# Altium schematic sheet JacdacModuleTesterEc30 91.SchDoc
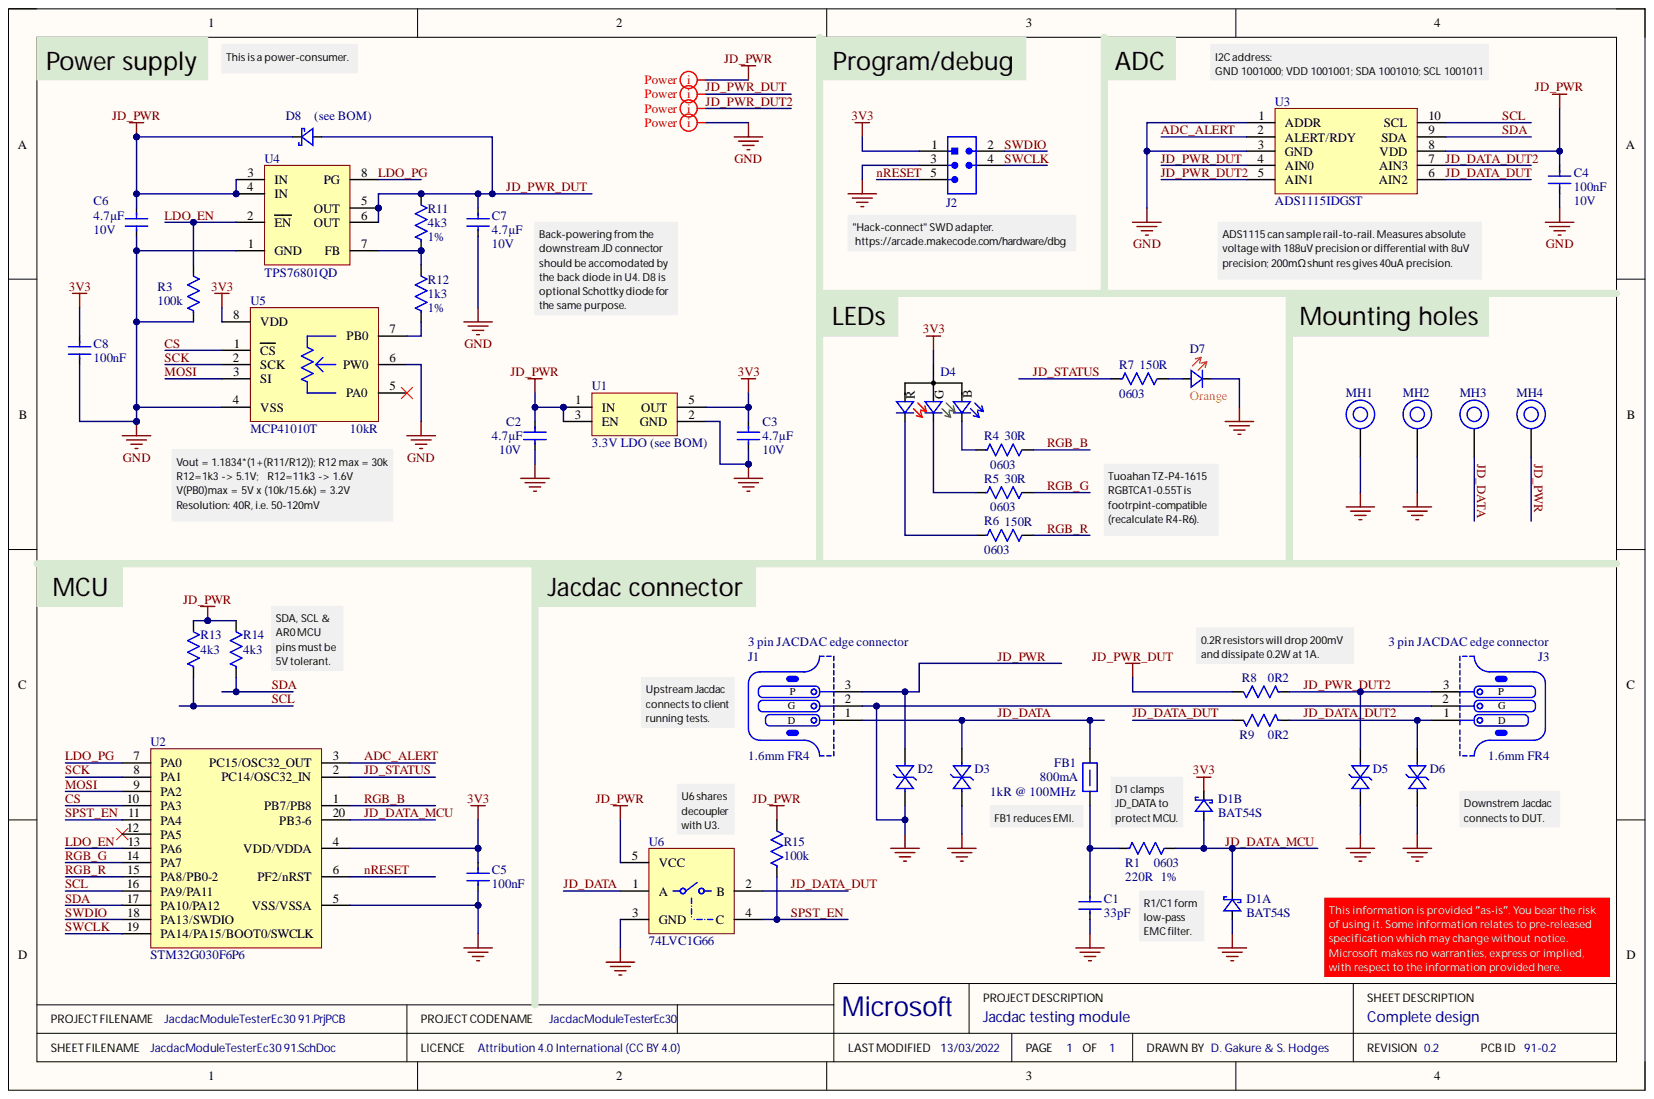
[diagram p0: JacdacModuleTesterEc30 91.SchDoc]

## Parts
C1  value 33pF
C2  Capacitance: 4.7µF  Voltage Rating: 10V
C3  Capacitance: 4.7µF  Voltage Rating: 10V
C4  value 100nF  Rated Voltage: 10V
C5  value 100nF
C6  Capacitance: 4.7µF  Voltage Rating: 10V
C7  Capacitance: 4.7µF  Voltage Rating: 10V
C8  value 100nF
D1  Generic Part Number: BAT54S  (parts A,B)
D2  (no value)
D3  (no value)
D4  (no value)
D5  (no value)
D6  (no value)
D7  Color: Orange
D8  Generic Part Number: (see BOM)
FB1  Current Rating: 800mA
J1  PCB Type: 1.6mm FR4
J2  (no value)
J3  PCB Type: 1.6mm FR4
MH1  (no value)
MH2  (no value)
MH3  (no value)
MH4  (no value)
R1  Case Code (Imperial): 0603  Resistance: 220R  Resistance Tolerance: 1%
R3  value 100k
R4  Case Code (Imperial): 0603  Resistance: 30R
R5  Case Code (Imperial): 0603  Resistance: 30R
R6  Case Code (Imperial): 0603  Resistance: 150R
R7  Case Code (Imperial): 0603  Resistance: 150R
R8  value 0R2
R9  value 0R2
R11  value 4k3  Tolerance: 1%
R12  value 1k3  Tolerance: 1%
R13  value 4k3
R14  value 4k3
R15  value 100k
U1  Manufacturer Part Number: 3.3V LDO (see BOM)  Min Output Voltage: 3.3V
U2  Manufacturer Part Number: STM32G030F6P6
U3  Manufacturer Part Number: ADS1115IDGST
U4  Manufacturer Part Number: TPS76801QD
U5  Resistance: 10kR
U6  (no value)

## Nets
3V3: C3.2 C5.2 C8.2 D1.2 D4.1 J2.1 U1.5(OUT) U2.4(VDD/VDDA) U5.8(VDD)
GND: C1.1 C2.1 C3.1 C4.1 C5.1 C6.1 C7.1 C8.1 D1.1 D2.2 D3.2 D5.2 D6.2 D7.1 J1.2 J2.3 J3.2 MH1.1 MH2.1 R3.2 U1.2(GND) U2.5(VSS/VSSA) U3.1(ADDR) U3.3(GND) U4.1(GND) U5.4(VSS) U5.6(PW0) U6.3(GND)
ADC_ALERT: U2.3(PC15/OSC32_OUT) U3.2(ALERT/RDY)
CS: U2.10(PA3) U5.1(CS)
JD_DATA: D3.1 FB1.1 J1.1 MH3.1 U6.1(A)
JD_DATA_DUT: R9.1 U3.6(AIN2) U6.2(B)
JD_DATA_DUT2: D6.1 J3.1 R9.2 U3.7(AIN3)
JD_DATA_MCU: D1.3 R1.2 U2.20(PB3-6)
JD_PWR: C2.2 C4.2 C6.2 D2.1 D8.1 J1.3 MH4.1 R13.1 R14.1 R15.1 U1.1(IN) U1.3(EN) U3.8(VDD) U4.3(IN) U4.4(IN) U6.5(VCC)
JD_PWR_DUT: C7.2 D8.2 R8.1 R11.1 U3.4(AIN0) U4.5(OUT) U4.6(OUT)
JD_PWR_DUT2: D5.1 J3.3 R8.2 U3.5(AIN1)
JD_STATUS: R7.1 U2.2(PC14/OSC32_IN)
LDO_EN: R3.1 U2.13(PA6) U4.2(EN)
LDO_PG: U2.7(PA0) U4.8(PG)
MOSI: U2.9(PA2) U5.3(SI)
nRESET: J2.5 U2.6(PF2/nRST)
RGB_B: R4.2 U2.1(PB7/PB8)
RGB_G: R5.2 U2.14(PA7)
RGB_R: R6.2 U2.15(PA8/PB0-2)
SCK: U2.8(PA1) U5.2(SCK)
SCL: R13.2 U2.16(PA9/PA11) U3.10(SCL)
SDA: R14.2 U2.17(PA10/PA12) U3.9(SDA)
SPST_EN: R15.2 U2.11(PA4) U6.4(C)
SWCLK: J2.4 U2.19(PA14/PA15/BOOT0/SWCLK)
SWDIO: J2.2 U2.18(PA13/SWDIO)
NetC1_2: C1.2 FB1.2 R1.1
NetD4_2: D4.2 R6.1
NetD4_3: D4.3 R5.1
NetD4_4: D4.4 R4.1
NetD7_2: D7.2 R7.2
NetR11_2: R11.2 R12.1 U4.7(FB)
NetR12_2: R12.2 U5.7(PB0)

Not connected: U2 12(PA5); U5 5(PA0)

## Library data of the parts
C1 = (no symbol name): Generic 0603 capacitor 33pF; Rated Voltage: 10V; Manufacturer: Generic; Manufacturer 1: Generic; Package Size: 0603
C2 C3 C6 C7 = (no symbol name): Generic 0603 capacitor 4.7uF 10V, e.g. Samsung CL10A475KP8NNNC; Dielectric: X5R; Tolerance: 10%; Case Code (Imperial): 0603; Case Code (Metric): 1608; Case/Package: 0603; Contact Plating: Tin; Manufacturer: Samsung; Manufacturer Part Number: CL10A475KP8NNNC; Max Operating Temperature: 85°C; Min Operating Temperature: -55°C; Supplier 1: Digi-Key; Supplier 2: Farnell; Supplier Part Number 1: 1276-1044-1-ND; Supplier Part Number 2: 3010071
C4 = (no symbol name): Generic 0603 capacitor 100nF; Manufacturer: Generic; Manufacturer 1: Generic; Package Size: 0603
C5 C8 = (no symbol name): Generic 0603 capacitor 100nF; Rated Voltage: 10V; Manufacturer: Generic; Manufacturer 1: Generic; Package Size: 0603
D1 = (no symbol name): DIODE ARRAY SCHOTTKY 30V SOT23; Manufacturer: Nexperia; Manufacturer Part Number: BAT54S,235; Category: Rectifier Diodes; ComponentLink1Description: NXP datasheet online; ComponentLink1URL: https://assets.nexperia.com/documents/data-sheet/BAT54_SER.pdf; Supplier 1: Digi-Key; Supplier 2: Mouser; Supplier 3: RSComponents; Supplier Part Number 1: 1727-1868-1-ND; Supplier Part Number 2: 771-BAT54S235; Supplier Part Number 3: 1707990
D2 D3 D5 D6 = (no symbol name): Bidirectional 5V (Max) 6V 16V 3.5A (8/20us) 0402 TVS RoHS; Manufacturer: Born Semiconductor; Manufacturer Part Number: RCLAMP0521P-N; ComponentLink1Description: Online datasheet; ComponentLink1URL: https://datasheet.lcsc.com/szlcsc/Bourne-Semicon-Shenzhen-RCLAMP0521P-N_C316043.pdf; Package: 0402; Supplier1: LCSC; Supplier1 Part Number: C316043
D4 = (no symbol name): RGB LED 0606 (1616 Metric); 626nm R, 525nm G, 470nm B; 1.9V R, 3.4V G, 3.4V B; Manufacturer: Broadcom Avago; Manufacturer Part Number: HSMF-C114; Color: Blue,Green,Red; Forward Current: 20mA; Lens Color: White; Lens Style: Diffused,Rectangular; Lens Transparency: Diffused; Luminous Intensity: 70mcd; Number of Elements: 3; Power Rating: 78mW; Reverse Voltage: 5V; Viewing Angle: 145°; Case Code (Imperial): 0606; Case Code (Metric): 1616; Case/Package: 0606; Category: Optoelectronics; ComponentLink1Description: Online datasheet; ComponentLink1URL: https://docs.broadcom.com/docs/AV02-2451EN; Dominant Wavelength: 470nm; Forward Voltage: 3.4V; Max Operating Temperature: 85°C; Min Operating Temperature: -40°C; Number of LEDs: 3; Number of Pins: 4; Supplier 1: Digi-Key; Supplier 2: Farnell; Supplier 3: Mouser; Supplier Part Number 1: 516-1795-1-ND; Supplier Part Number 2: 1058362; Supplier Part Number 3: 630-HSMF-C114; Supplier Part Number 10: ?; Test Current: 20mA; Wavelength: 470nm
D7 = (no symbol name): LED ORANGE DIFFUSED 0603 SMD; Manufacturer: Everlight Elec; Manufacturer Part Number: 19-217/S2C-AQ1R1B/3T; Case Code (Imperial): 0603; Case/Package: 0603; Colour: Orange; ComponentLink1Description: Online datasheet; ComponentLink1URL: https://datasheet.lcsc.com/lcsc/1912171731_Everlight-Elec-19-217-S2C-AQ1R1B-3T_C146215.pdf; Supplier 1: LCSC; Supplier 1 Part Number: C146215
D8 = (no symbol name): DIODE SCHOTTKY 20V 1A SOD123FL; Manufacturer: ON Semiconductor; Manufacturer Part Number: MBR120VLSFT3G; Element Configuration: Single; Forward Voltage: 340mV; Max Forward Surge Current (Ifsm): 45A; Max Reverse Leakage Current: 600uA; Polarity: Standard; Average Rectified Current: 1A; Category: Schottky Diodes; ComponentLink4Description: On Semi datasheet online; ComponentLink4URL: https://datasheet.ciiva.com/6380/mbr120vlsft1-d-6380910.pdf; Current Rating: 1A; Forward Current: 1A; Halogen Free: Halogen Free; Max Operating Temperature: 125°C; Max Repetitive Reverse Voltage (Vrrm): 20V; Max Reverse Voltage (DC): 20V; Max Surge Current: 45A; Min Operating Temperature: -65°C; Number of Pins: 2; Output Current: 1A; Peak Non-Repetitive Surge Current: 45A; Peak Reverse Current: 600uA; Supplier 1: Digi-Key; Supplier 2: Mouser; Supplier 3: RSComponents; Supplier Part Number 1: MBR120VLSFT3GOSCT-ND; Supplier Part Number 2: 863-MBR120VLSFT3G; Supplier Part Number 3: 1867479; Voltage Rating (DC): 20V
FB1 = (no symbol name): 0603 1k@100MHz 0.8A chip; Manufacturer: TDK; Manufacturer Part Number: MPZ1608S102ATA00; Core Material: Ferrite; DC Resistance (DCR): 300mR; Impedance: 1kR @ 100MHz; Tolerance: 25%; Case Code (Imperial): 0603; Case Code (Metric): 1608; Case/Package: 0603; ComponentLink2Description: datasheet online; ComponentLink2URL: https://www.mouser.co.uk/datasheet/2/400/eads_commercial_power_mpz1608_en-923650.pdf; ComponentLink3Description: datasheet; Max DC Current: 800mA; Supplier 1: Digi-Key; Supplier 2: Mouser; Supplier Part Number 1: 445-8694-1-ND; Supplier Part Number 2: 810-MPZ1608S102ATA00; ComponentLink3URL: Y:\System\Altium\Libraries 2014\TDK\Datasheets\eads_commercial_power_mpz1608_en-923650.pdf
J1 J3 = (no symbol name): 3 pin Jacdac edge connector 1.27mm pitch; Manufacturer Part Number: JACDAC_EDGE_CONNECTOR; ComponentLink1Description: Yuliang JACDAC cable connector drawing online; ComponentLink1URL: https://github.com/microsoft/jacdac-mdk/blob/main/connector/JACDAC-Connector-by-Yuliang-2020-11-28.pdf; ComponentLink2Description: JACDAC PCB edge connector spec onlin; ComponentLink2URL: https://github.com/microsoft/jacdac-mdk/blob/main/connector/JACDAC_PCB_Edge_Connector_Drawing_JD-PEC-01_rev_01.pdf
J2 = (no symbol name): Hack Connect Mini 1.27 mm pitch 2-row
MH1-MH4 = (no symbol name): Mounting Hole 7.0mm keepout, 4.4mm annualar ring and 3.1mm Drill PTH
R1 = (no symbol name): Res Thick Film 0603 220 Ohm 1% 0.1W ±100ppm/°C SMD; Manufacturer: Yageo; Manufacturer Part Number: RC0603FR-07220RL; Max Power Dissipation: 100mW; Voltage Rating: 50V; Case Code (Metric): 1608; Case/Package: 0603; Category: Chip SMD Resistors; ComponentLink1Description: Online datasheet; ComponentLink1URL: https://datasheet.ciiva.com/11819/0900766b80f96399-11819290.pdf; Construction: Rectangular; Features: Moisture Resistant; Manufacturer 1: Yageo; Manufacturer Part Number 1: RC0603FR-07220RL; Max Operating Temperature: 155°C; Min Operating Temperature: -55°C; Number of Pins: 2; Number of Terminations: 2; Power Rating: 100mW; Resistor Type: Thick Film; RoHS Compliant: Yes; Series: RC; Size Code: 0603; Supplier 1: Digi-Key; Supplier 2: Farnell; Supplier 3: RSComponents; Supplier 4: Mouser; Supplier 5: LCSC; Supplier Part Number 1: 311-220HRCT-ND; Supplier Part Number 2: 9238409; Supplier Part Number 3: 1995497; Supplier Part Number 4: 603-RC0603FR-07220RL; Supplier Part Number 5: C107696; Temperature Coefficient: 100ppm/°C; Termination: SMD/SMT; Tolerance: 1%; Voltage Rating (DC): 50V
R3 R15 = (no symbol name): Generic 0603 chip resistor 100k; Power: *mW; Tolerance: 5%; Manufacturer: Generic; Manufacturer 1: Generic; Package Size: 0603
R4 R5 = (no symbol name): Res Thick Film 0603 30 Ohm 1% 0.1W ±100ppm/°C SMD; Manufacturer: Yageo; Manufacturer Part Number: RC0603FR-0730RL; Max Power Dissipation: 100mW; Voltage Rating: 50V; Case Code (Metric): 1608; Case/Package: 0603; Category: Chip SMD Resistors; ComponentLink1Description: Online datasheet; ComponentLink1URL: https://datasheet.ciiva.com/11819/0900766b80f96399-11819290.pdf; Construction: Rectangular; Features: Moisture Resistant; Manufacturer 1: Yageo; Manufacturer Part Number 1: RC0603FR-0730RL; Max Operating Temperature: 155°C; Min Operating Temperature: -55°C; Number of Terminations: 2; Power Rating: 100mW; Resistor Type: Thick Film; RoHS Compliant: Yes; Series: RC; Size Code: 0603; Supplier 1: Digi-Key; Supplier 2: Farnell; Supplier 3: Mouser; Supplier 5: LCSC; Supplier Part Number 1: 311-30.0HRCT-ND; Supplier Part Number 2: 3495813; Supplier Part Number 3: 603-RC0603FR-0730RL; Supplier Part Number 5: C128060; Technology: THICK FILM; Temperature Coefficient: 100ppm/°C; Termination: SMD/SMT; Tolerance: 1%; Voltage Rating (DC): 50V
  R4: ComponentLink2Description: https://datasheet.ciiva.com/11819/0900766b80c66297-11819676.pdf?src-supplier=RSComponents; ComponentLink2URL: https://datasheet.ciiva.com/11819/0900766b80c66297-11819676.pdf?src-supplier=RSComponents; ComponentLink3Description: https://datasheet.ciiva.com/2455/pyu-r-int-thick-7-2455119.pdf?src-supplier=Digi-Key; ComponentLink3URL: https://datasheet.ciiva.com/2455/pyu-r-int-thick-7-2455119.pdf?src-supplier=Digi-Key; ComponentLink4Description: https://datasheet.ciiva.com/2455/pyu-r-int-thick-7-2455120.pdf?src-supplier=Digi-Key; ComponentLink4URL: https://datasheet.ciiva.com/2455/pyu-r-int-thick-7-2455120.pdf?src-supplier=Digi-Key; ComponentLink5Description: https://datasheet.ciiva.com/2455/pyu-r-int-thick-7-2455121.pdf?src-supplier=Digi-Key; ComponentLink5URL: https://datasheet.ciiva.com/2455/pyu-r-int-thick-7-2455121.pdf?src-supplier=Digi-Key
R6 = (no symbol name): Res Thick Film 0603 150 Ohm 1% 1/10W ±100ppm/°C SMD; Manufacturer: Yageo; Manufacturer Part Number: RC0603FR-07150RL; Max Power Dissipation: 100mW; Voltage Rating: 50V; Case Code (Metric): 1608; Case/Package: 0603; Category: Chip SMD Resistors; ComponentLink1Description: Online datasheet; ComponentLink1URL: https://datasheet.ciiva.com/11819/0900766b80f96399-11819290.pdf; Construction: Rectangular; Features: Moisture Resistant; Manufacturer 1: Yageo; Manufacturer Part Number 1: RC0603FR-07150RL; Max Operating Temperature: 155°C; Min Operating Temperature: -55°C; Number of Pins: 2; Number of Terminations: 2; Power Rating: 100mW; Resistance Tolerance: 1%; Resistor Type: Thick Film; RoHS Compliant: Yes; Series: RC; Size Code: 0603; Supplier 1: Digi-Key; Supplier 2: Farnell; Supplier 3: RSComponents; Supplier 4: Mouser; Supplier 5: LCSC; Supplier Part Number 1: 311-150HRCT-ND; Supplier Part Number 2: 9238387; Supplier Part Number 3: 1995464; Supplier Part Number 4: 603-RC0603FR-07150RL; Supplier Part Number 5: C114608; Temperature Coefficient: 100ppm/°C; Termination: SMD/SMT; Tolerance: 1%; Voltage Rating (DC): 50V
R7 = (no symbol name): Res Thick Film 0603 150 Ohm 1% 1/10W ±100ppm/°C SMD; Manufacturer 1: Yageo; Manufacturer Part Number 1: RC0603FR-07150RL; Max Power Dissipation: 100mW; Voltage Rating: 50V; Case Code (Metric): 1608; Case/Package: 0603; Category: Chip SMD Resistors; ComponentLink1Description: Online datasheet; ComponentLink1URL: https://datasheet.ciiva.com/11819/0900766b80f96399-11819290.pdf; Construction: Rectangular; Features: Moisture Resistant; Max Operating Temperature: 155°C; Min Operating Temperature: -55°C; Number of Pins: 2; Number of Terminations: 2; Power Rating: 100mW; Resistance Tolerance: 1%; Resistor Type: Thick Film; RoHS Compliant: Yes; Series: RC; Size Code: 0603; Supplier 1: Digi-Key; Supplier 2: Farnell; Supplier 3: RSComponents; Supplier 4: Mouser; Supplier 5: LCSC; Supplier Part Number 1: 311-150HRCT-ND; Supplier Part Number 2: 9238387; Supplier Part Number 3: 1995464; Supplier Part Number 4: 603-RC0603FR-07150RL; Supplier Part Number 5: C114608; Temperature Coefficient: 100ppm/°C; Termination: SMD/SMT; Tolerance: 1%; Voltage Rating (DC): 50V
R8 R9 = (no symbol name): Res 200mOhm 1% 1/2W 1206; Manufacturer: Ohmite; Manufacturer Part Number: KDV12FR200ET; Power: 500mW; Category: Chip SMD Resistors; ComponentLink1Description: Ohmite datasheet online; ComponentLink1URL: https://www.ohmite.com/assets/docs/res_kdv.pdf; Manufacturer 1: Ohmite; Manufacturer Part Number 1: KDV12FR200ET; Package Size: 1206; Supplier 1: Digi-Key; Supplier 2: Farnell; Supplier 3: Mouser; Supplier Part Number 1: 273-KDV12FR200ETCT-ND; Supplier Part Number 2: 3273737; Supplier Part Number 3: 588-KDV12FR200ET; Tolerance: 1%
R11 = (no symbol name): Generic 0603 chip resistor 4k3; Power: *mW; Manufacturer: Generic; Manufacturer 1: Generic; Package Size: 0603
R12 = (no symbol name): Generic 0603 chip resistor 1k3; Power: *mW; Manufacturer: Generic; Manufacturer 1: Generic; Package Size: 0603
R13 R14 = (no symbol name): Generic 0603 chip resistor 4k3; Power: *mW; Tolerance: 1%; Manufacturer: Generic; Manufacturer 1: Generic; Package Size: 0603
U1 = (no symbol name): 3.3V LDO. Vin 6V max, Vf 260mV @ 200mA (typ); Manufacturer: MICRONE(Nanjing Micro One Elec); Dropout Voltage: 200mV; Max Input Voltage: 6; Number of Regulators: 1; Output Configuration: Positive; Output Current: 500mA; Output Type: Fixed; Case/Package: SOT-23-5; Category: Voltage Regulators - Linear; ComponentLink3Description: On Semi datasheet online; ComponentLink3URL: https://datasheet.lcsc.com/lcsc/1811131510_MICRONE-Nanjing-Micro-One-Elec-ME6211C33M5G-N_C82942.pdf; Manufacturer 1: MICRONE(Nanjing Micro One Elec); Manufacturer Part Number 1: 3.3V LDO (see BOM); Supplier 1: LCSC; Supplier Part Number: C82942
U2 = (no symbol name): ARM® Cortex®-M0+ series IC 64MHz 32KB 20-TSSOP; Manufacturer: STMicroelectronics; Category: Microcontrollers; ComponentLink1Description: Online datasheet; ComponentLink1URL: https://www.st.com/resource/en/datasheet/stm32g030f6.pdf; ComponentLink2Description: Online reference manual; ComponentLink2URL: https://www.st.com/resource/en/reference_manual/rm0454-stm32g0x0-advanced-armbased-32bit-mcus-stmicroelectronics.pdf; Manufacturer 1: STMicroelectronics; Manufacturer Part Number 1: STM32G030F6P6; RoHS Compliant: Yes; Supplier 1: Digi-Key; Supplier 2: Farnell; Supplier 3: Mouser; Supplier 10: LCSC; Supplier Part Number 1: 497-STM32G030F6P6-ND; Supplier Part Number 2: 3294301; Supplier Part Number 3: 511-STM32G030F6P6; Supplier Part Number 10: C724040
U3 = (no symbol name): IC ADC 16-BIT I2C PROGBL 10-MSOP; Manufacturer: Texas Instruments (TI); Architecture: Delta-Sigma; Differential Input: Yes; Digital Interface Type: Serial (2-Wire, I2C); Digital Supply Support: No; Full Scale Error: 0.15 % of FSR; Input Signal Type: Single-Ended|Differential; Input Type: Voltage; Input Voltage: 4.096 V; Integral Nonlinearity Error: 1 LSB; Lead Finish: Gold; Max Processing Temp: 260; Maximum Single Supply Voltage: 5.5 V; Minimum Single Supply Voltage: 2 V; Number of ADCs: 1; Number of Analog Inputs: 4|2; Polarity of Input Voltage: Bipolar; Resolution: 16 Bit; Sampling Rate: 860 sps; Typical Power Dissipation: 0.9 mW; Typical Single Supply Voltage: 3.3 V; Voltage Reference: Internal; Voltage Supply Source: Single; Category: ADC; ComponentLink1Description: online datasheet; ComponentLink1URL: http://www.ti.com/lit/ds/symlink/ads1115.pdf; Mounting: Surface Mount; Operating Temperature: -40 to 125 C; Package: 10VSSOP; Sample and Hold: No; Single-Ended Input: Yes; Supplier 1: Digikey; Supplier Part Number 1: 296-24934-1-ND
U4 = (no symbol name): 1-A, 10-V, low-dropout voltage regulator with power good & enable 8-SOIC -40 to 125; Manufacturer: Texas Instruments; Accuracy: 2%; Current Rating: 1A; Dropout Voltage: 230mV; Input Bias Current: 85uA; Max Input Voltage: 10V; Max Output Voltage: 5.5V; Max Power Dissipation: 904.15mW; Min Current Limit: 1.2A; Min Input Voltage: 2.7V; Min Output Voltage: 1.2V; Number of Outputs: 1; Output Type: Adjustable,Fixed; Polarity: Positive; Quiescent Current: 80uA; Reference Voltage: 1.1834V; Case/Package: D; Category: Voltage Regulators - Linear; ComponentLink1Description: TI datasheet online; ComponentLink1URL: https://datasheet.lcsc.com/lcsc/1810181640_Texas-Instruments-TPS76801QDR_C20030.pdf; Manufacturer 1: Texas Instruments; Manufacturer Part Number 1: TPS76801QD; Max Operating Temperature: 125°C; Max Output Current: 1A; Min Operating Temperature: -40°C; Number of Pins: 8; Number of Regulators: 1; Output Current: 1A; Output Voltage: 5.5V; Power Dissipation: 904.15mW; Power Rating: 904.15mW; RoHS Compliant: Yes; Series: -; Supplier 1: Digi-Key; Supplier 2: Farnell; Supplier 3: RSComponents; Supplier 4: Mouser; Supplier Part Number 1: 296-2743-5-ND; Supplier Part Number 2: 3122534; Supplier Part Number 3: 1624919; Supplier Part Number 4: 595-TPS76801QD
U5 = (no symbol name): Digital Pot; 256 steps; SPI; 10kohms; single channel8 SOIC 3.90mm(.150in) T/R; Manufacturer: Microchip; Manufacturer Part Number: MCP41010T-I/SN; Frequency: 10MHz; Interface: SPI,Serial; Max Supply Voltage: 5.5V; Memory Type: Volatile; Min Supply Voltage: 2.7V; Nominal Supply Current: 340uA; Number of Channels: 1; Number of Positions: 256; Quiescent Current: 10nA; Temperature Coefficient: 800ppm/°C; Tolerance: 20%; Voltage: 5V; Case/Package: SOIC; Category: Digital Potentiometers; ComponentLink1Description: Microchip datasheet online; ComponentLink1URL: https://ww1.microchip.com/downloads/en/DeviceDoc/11195c.pdf; Manufacturer 1: Microchip; Manufacturer Part Number 1: MCP41010T-I/SN; Max Operating Temperature: 85°C; Min Operating Temperature: -40°C; Number of Circuits: 1; Number of Elements: 1; Number of Pins: 8; Number of Taps: 256; Resistor Type: Potentiometer; RoHS Compliant: Yes; Series: -; Supplier 1: Digi-Key; Supplier 2: Farnell; Supplier 3: Mouser; Supplier Part Number 1: MCP41010T-I/SNCT-ND; Supplier Part Number 2: 2857765; Supplier Part Number 3: 579-MCP41010T-I/SN; Supply Current: 340uA; Taper: Linear
U6 = (no symbol name): 1 Circuit IC Switch 1:1 10 Ohm SOT-23-5; Manufacturer: Texas Instruments; Manufacturer Part Number: SN74LVC1G66DBVR; Bandwidth: 195MHz; High Level Output Current: 50mA; Max Supply Current: 10uA; Max Supply Voltage: 5.5V; Min Supply Voltage: 1.65V; Nominal Supply Current: 1uA; Number of Bits: 1; On-State Resistance: 10R; Polarity: Non-Inverting; Propagation Delay: 600ps; Supply Type: Single; Throw Configuration: SPST; Turn-Off Delay Time: 5ns; Turn-On Delay Time: 4.2ns; Case/Package: SOT-23; Category: Interface ICs; ComponentLink1Description: online datasheet; ComponentLink1URL: https://datasheet.lcsc.com/lcsc/1809251629_Texas-Instruments-SN74LVC1G66DBVR_C133643.pdf; Contact Plating: Gold; Low Level Output Current: 50mA; Manufacturer 1: Texas Instruments; Manufacturer Part Number 1: SN74LVC1G66DBVR; Max Operating Temperature: 85°C; Min Operating Temperature: -40°C; Number of Channels: 1; Number of Inputs: 1; Number of Outputs: 1; Number of Pins: 5; Operating Supply Current: 10uA; Output Current: 50mA; RoHS Compliant: Yes; Series: 74LVC; Supplier 1: Digi-Key; Supplier 2: Farnell; Supplier 3: RSComponents; Supplier 4: Mouser; Supplier Part Number 1: 296-13253-1-ND; Supplier Part Number 2: 3118254; Supplier Part Number 3: 8096012; Supplier Part Number 4: 595-SN74LVC1G66DBVR; Supply Current: 1uA; Termination: SMD/SMT; Weight: 0.000395oz
